# Altium schematic sheet Interface1.schdoc(Interface1)
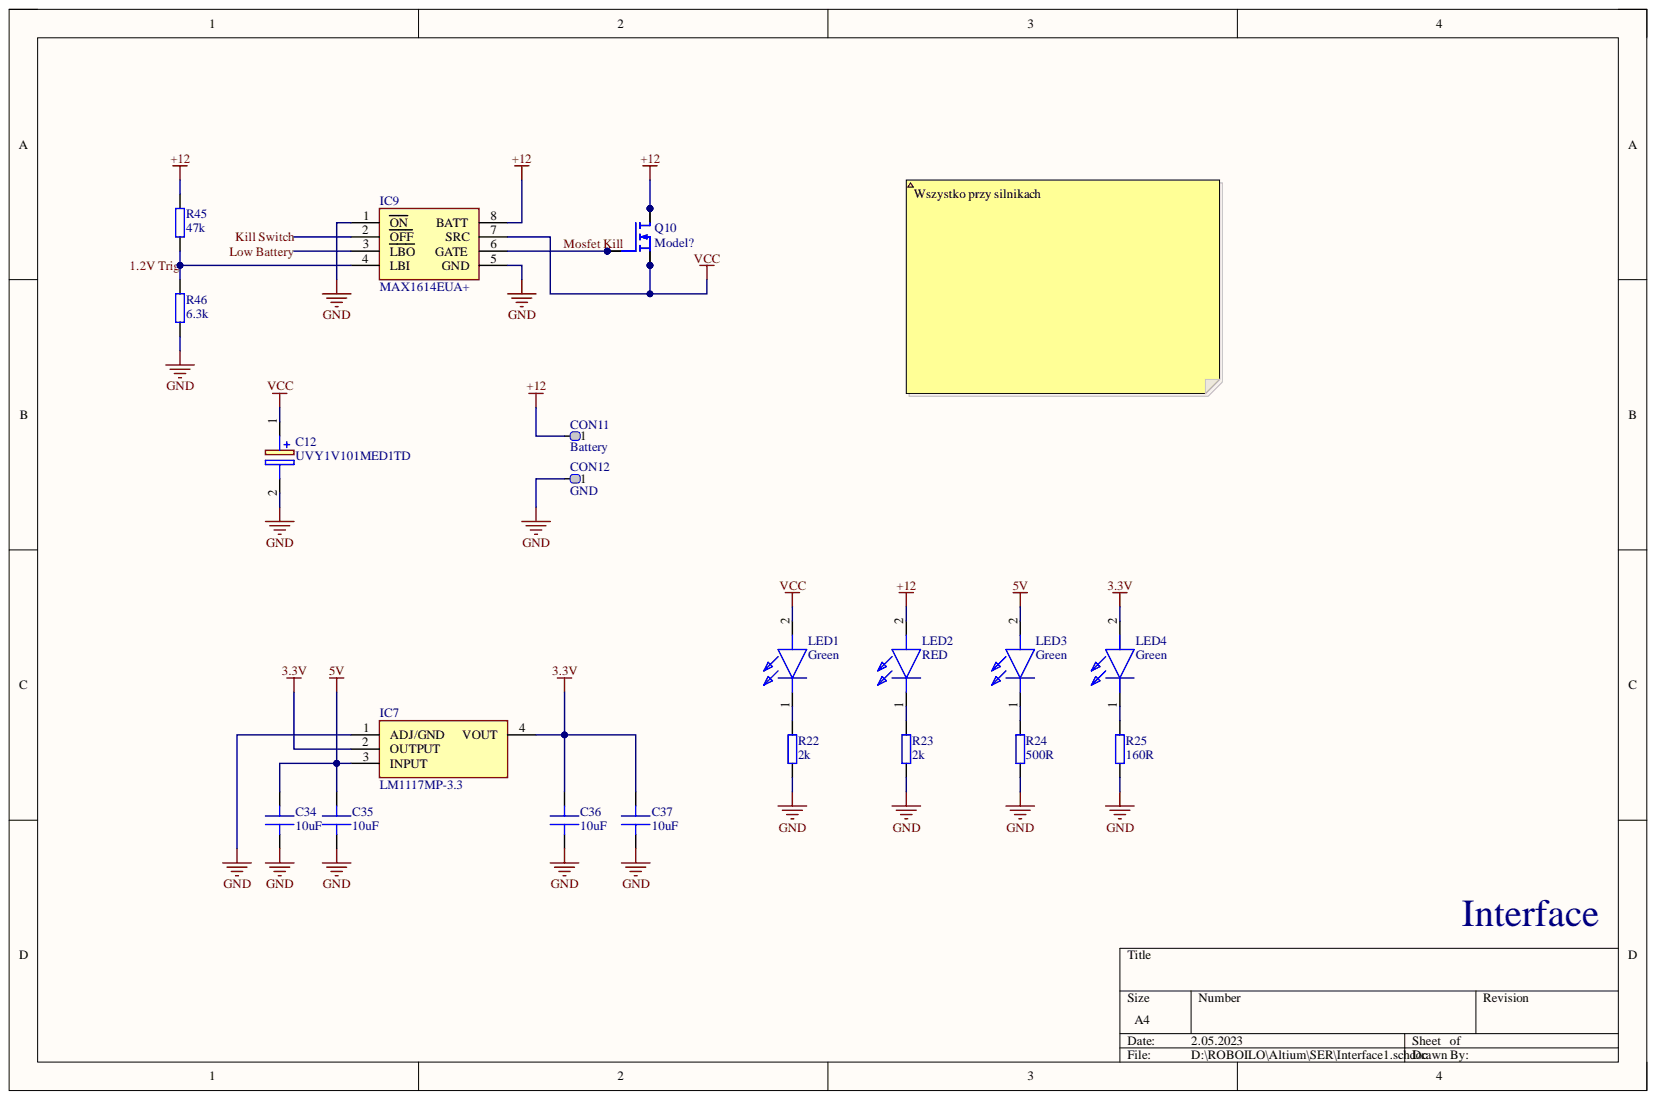
[diagram p0: Interface1.schdoc]

## Parts
C12  UVY1V101MED1TD  [CAPPRD250W50D655H1250]
C34  10uF  [C 0805]
C35  10uF  [C 0805]
C36  10uF  [C 0805]
C37  10uF  [C 0805]
CON11  Battery  [SMD PAD]
CON12  GND  [SMD PAD]
IC7  LM1117MP-3.3  [SOT230P700X180-4N]
IC9  MAX1614EUA+  [SOP65P490X110-8N]
LED1  Green  [LEDC1608X50N]
LED2  RED  [LEDC1608X50N]
LED3  Green  [LEDC1608X50N]
LED4  Green  [LEDC1608X50N]
Q10  Model?  [DFN 5x6]
R22  2k  [R 0402]
R23  2k  [R 0402]
R24  500R  [R 0402]
R25  160R  [R 0402]
R45  47k  [R 0402]
R46  6.3k  [R 0402]

## Nets
3.3V: C36.1 C37.1 IC7.2(OUTPUT) IC7.4(VOUT) LED4.2
5V: C34.1 C35.1 IC7.3(INPUT) LED3.2
+12: CON11.1 IC9.8(BATT) LED2.2 Q10.5_8 R45.2
GND: C12.2 C34.2 C35.2 C36.2 C37.2 CON12.1 IC7.1(ADJ/GND) IC9.1(ON) IC9.5(GND) R22.2 R23.2 R24.2 R25.2 R46.1
VCC: C12.1 IC9.7(SRC) LED1.2 Q10.1,2,3 Q10.1, 2, 3
1.2V Trig: IC9.4(LBI) R45.1 R46.2
Kill Switch: IC9.2(OFF)
Low Battery: IC9.3(LBO)
Mosfet Kill: IC9.6(GATE) Q10.4
NetLED1_1: LED1.1 R22.1
NetLED2_1: LED2.1 R23.1
NetLED3_1: LED3.1 R24.1
NetLED4_1: LED4.1 R25.1

## Library data of the parts
C12 = UVY1V101MED1TD: Capacitor Polarised; Manufacturer_Name: Nichicon; Arrow Part Number: UVY1V101MED1TD; Arrow Price/Stock: https://www.arrow.com/en/products/uvy1v101med1td/nichicon; Component Kind: Standard; Datasheet Link: http://nichicon-us.com/english/products/pdfs/e-uvy.pdf; Height: 12.5mm; Library Name: SamacSys.SchLib; Manufacturer_Part_Number: UVY1V101MED1TD
C34-C37 = Capacitor: MLCC Capacitor; Component Kind: Standard; Library Name: Components.SchLib
CON11 CON12 = 1 Pin: Component Kind: Standard; Library Name: Connectors.SchLib
IC7 = LM1117MP-3.3: Integrated Circuit; Manufacturer_Name: Texas Instruments; Arrow Part Number: LM1117MP-3.3; Arrow Price/Stock: https://www.arrow.com/en/products/lm1117mp-3.3/texas-instruments; Component Kind: Standard; Datasheet Link: https://datasheet.datasheetarchive.com/originals/distributors/Datasheets-22/DSA-425268.pdf; Height: 1.8mm; Manufacturer_Part_Number: LM1117MP-3.3; Mouser Part Number: 926-LM1117MP-33; Mouser Price/Stock: https://www.mouser.co.uk/ProductDetail/Texas-Instruments/LM1117MP-33?qs=X1J7HmVL2ZEQQ0I3asZfvg%3D%3D
IC9 = MAX1614EUA+: Integrated Circuit; Manufacturer_Name: Maxim Integrated; Component Kind: Standard; Datasheet Link: http://datasheets.maximintegrated.com/en/ds/MAX1614.pdf; Height: 1.1mm; Library Name: SamacSys.SchLib; Manufacturer_Part_Number: MAX1614EUA+; Mouser Part Number: 700-MAX1614EUA; Mouser Price/Stock: https://www.mouser.co.uk/ProductDetail/Maxim-Integrated/MAX1614EUA%2b?qs=1THa7WoU59FiDBRRbRrFJA%3D%3D
LED1-LED4 = 150060GS55040: LED; Manufacturer_Name: Wurth Elektronik; Component Kind: Standard; Datasheet Link: https://katalog.we-online.de/led/datasheet/150060GS55040.pdf; Height: 0.5mm; Manufacturer_Part_Number: 150060GS55040; Mouser Part Number: 710-150060GS55040; Mouser Price/Stock: https://www.mouser.co.uk/ProductDetail/Wurth-Elektronik/150060GS55040?qs=fAHHVMwC%252Bbhr5Aln2oA6Nw%3D%3D; Library Name: LibraryLoader.SchLib
Q10 = Power MOSFET: N-Mosfet DFN 5x6; Component Kind: Standard; Library Name: Components.SchLib
R22-R25 R45 R46 = Resistor: General pourpouse resistor; Component Kind: Standard; Library Name: Components.SchLib
